# Altium schematic sheet POWER_RF.SchDoc
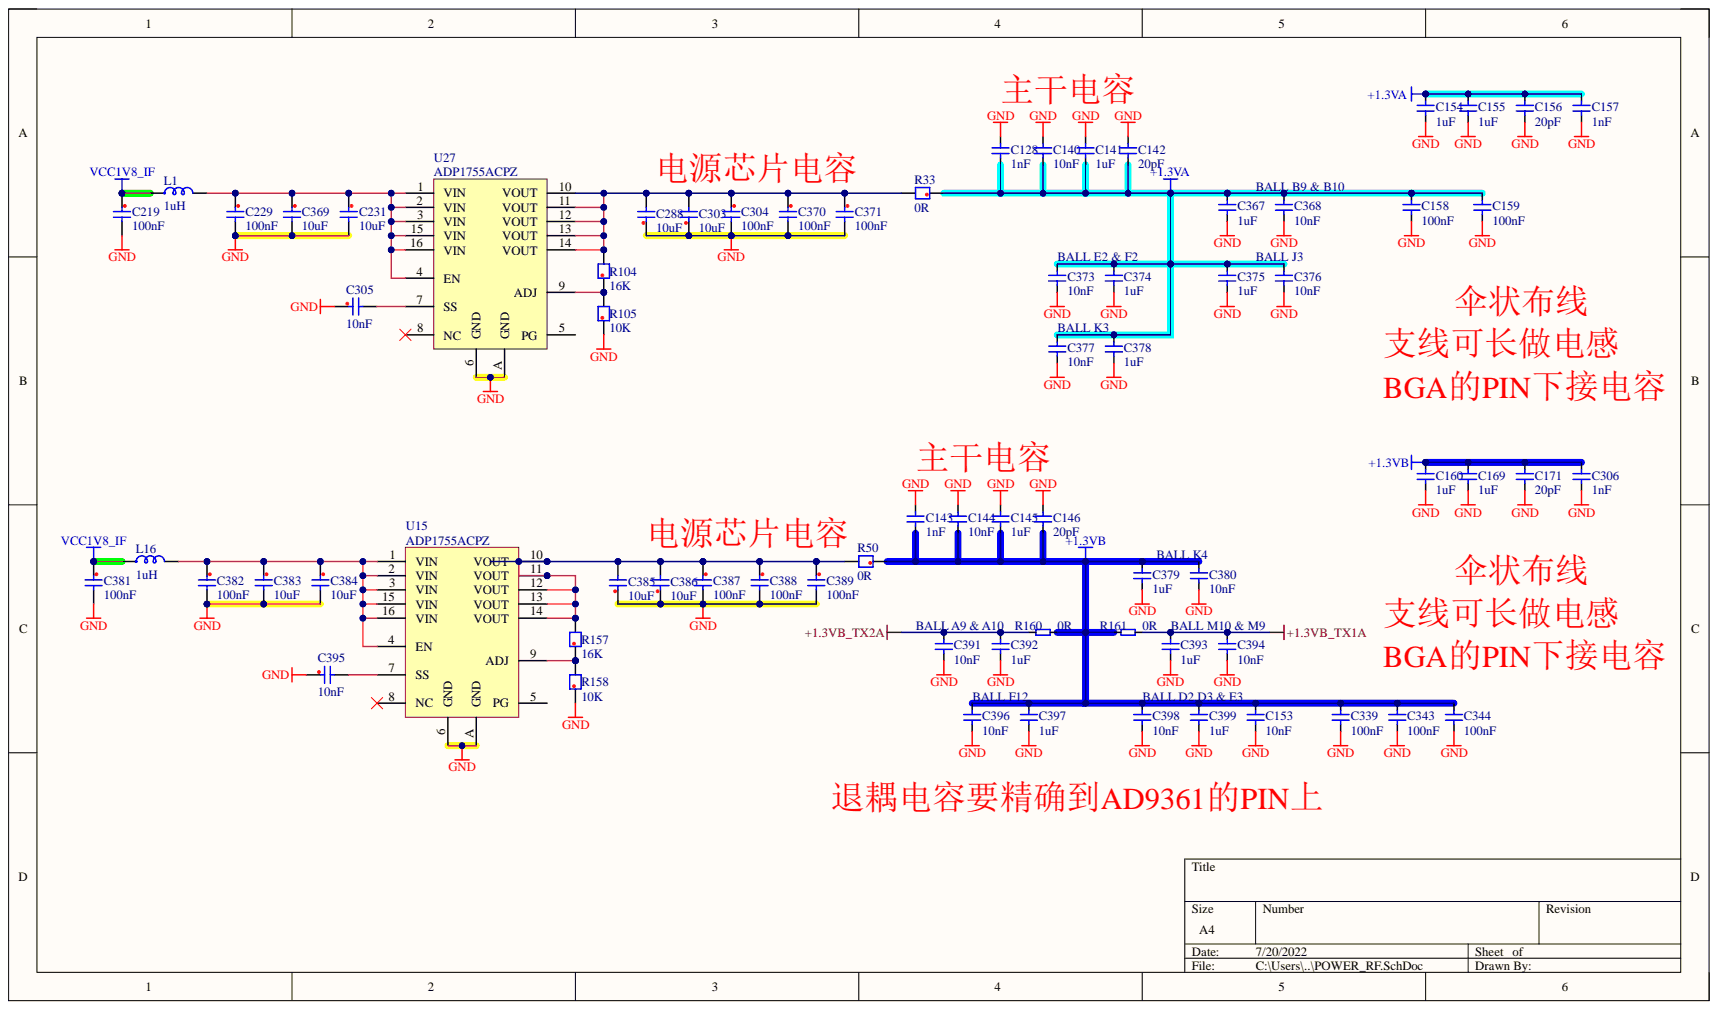
[diagram p0: POWER_RF.SchDoc]

## Parts
C128  1nF  [C0201]
C140  10nF  [C0201]
C141  1uF  [C0402]
C142  20pF  [C0201]
C143  1nF  [C0201]
C144  10nF  [C0201]
C145  1uF  [C0402]
C146  20pF  [C0201]
C153  10nF  [C0201]
C154  1uF  [C0402]
C155  1uF  [C0402]
C156  20pF  [C0201]
C157  1nF  [C0201]
C158  100nF  [C0201]
C159  100nF  [C0201]
C160  1uF  [C0402]
C169  1uF  [C0402]
C171  20pF  [C0201]
C219  100nF  [C0402]
C229  100nF  [C0402]
C231  10uF  [C0603]
C288  10uF  [C0603]
C303  10uF  [C0603]
C304  100nF  [C0201]
C305  10nF  [C0402]
C306  1nF  [C0201]
C339  100nF  [C0201]
C343  100nF  [C0201]
C344  100nF  [C0201]
C367  1uF  [C0402]
C368  10nF  [C0201]
C369  10uF  [C0603]
C370  100nF  [C0201]
C371  100nF  [C0201]
C373  10nF  [C0201]
C374  1uF  [C0402]
C375  1uF  [C0402]
C376  10nF  [C0201]
C377  10nF  [C0201]
C378  1uF  [C0402]
C379  1uF  [C0402]
C380  10nF  [C0201]
C381  100nF  [C0402]
C382  100nF  [C0402]
C383  10uF  [C0603]
C384  10uF  [C0603]
C385  10uF  [C0603]
C386  10uF  [C0603]
C387  100nF  [C0201]
C388  100nF  [C0201]
C389  100nF  [C0201]
C391  10nF  [C0201]
C392  1uF  [C0402]
C393  1uF  [C0402]
C394  10nF  [C0201]
C395  10nF  [C0402]
C396  10nF  [C0201]
C397  1uF  [C0402]
C398  10nF  [C0201]
C399  1uF  [C0402]
L1  1uH  [L0402]
L16  1uH  [L0402]
R33  0R  [R0402]
R50  0R  [R0402]
R104  16K  [R0402]
R105  10K  [R0402]
R157  16K  [R0402]
R158  10K  [R0402]
R160  0R  [R0201]
R161  0R  [R0201]
U15  ADP1755ACPZ  [QFN16A]
U27  ADP1755ACPZ  [QFN16A]

## Nets
+1.3VA: C128.2 C140.2 C141.2 C142.2 C154.2 C155.2 C156.1 C157.1 C158.2 C159.2 C367.2 C368.2 C373.2 C374.2 C375.2 C376.2 C377.2 C378.2 R33.1
+1.3VB: C143.2 C144.2 C145.2 C146.2 C153.2 C160.2 C169.2 C171.1 C306.1 C339.2 C343.2 C344.2 C379.2 C380.2 C396.2 C397.2 C398.2 C399.2 R50.1 R160.1 R161.2
+1.3VB_TX1A: C393.2 C394.2 R161.1
+1.3VB_TX2A: C391.2 C392.2 R160.2
GND: C128.1 C140.1 C141.1 C142.1 C143.1 C144.1 C145.1 C146.1 C153.1 C154.1 C155.1 C156.2 C157.2 C158.1 C159.1 C160.1 C169.1 C171.2 C219.2 C229.2 C231.2 C288.1 C303.1 C304.2 C305.1 C306.2 C339.1 C343.1 C344.1 C367.1 C368.1 C369.2 C370.2 C371.2 C373.1 C374.1 C375.1 C376.1 C377.1 C378.1 C379.1 C380.1 C381.2 C382.2 C383.2 C384.2 C385.1 C386.1 C387.2 C388.2 C389.2 C391.1 C392.1 C393.1 C394.1 C395.1 C396.1 C397.1 C398.1 C399.1 R105.1 R158.1 U15.6(GND) U15.A(GND) U27.6(GND) U27.A(GND)
VCC1V8_IF: C219.1 C381.1 L1.1 L16.1
NetC229_1: C229.1 C231.1 C369.1 L1.2 U27.1(VIN) U27.2(VIN) U27.3(VIN) U27.4(EN) U27.15(VIN) U27.16(VIN)
NetC288_2: C288.2 C303.2 C304.1 C370.1 C371.1 R33.2 R104.2 U27.10(VOUT) U27.11(VOUT) U27.12(VOUT) U27.13(VOUT) U27.14(VOUT)
NetC305_2: C305.2 U27.7(SS)
NetC382_1: C382.1 C383.1 C384.1 L16.2 U15.1(VIN) U15.2(VIN) U15.3(VIN) U15.4(EN) U15.15(VIN) U15.16(VIN)
NetC385_2: C385.2 C386.2 C387.1 C388.1 C389.1 R50.2 R157.2 U15.10(VOUT) U15.11(VOUT) U15.12(VOUT) U15.13(VOUT) U15.14(VOUT)
NetC395_2: C395.2 U15.7(SS)
NetR104_1: R104.1 R105.2 U27.9(ADJ)
NetR157_1: R157.1 R158.2 U15.9(ADJ)

Not connected: U15 5(PG) 8(NC); U27 5(PG) 8(NC)

## Library data of the parts
C128 C140-C146 C153-C160 C169 C171 C306 C339 C343 C344 C367 C368 C373-C380 C391-C394 C396-C399 = C: Capacitor; Component Kind: Standard; Library Name: 电容-SCH.LIB
C219 C229 C231 C288 C303-C305 C369-C371 C381-C389 C395 = CAP: Component Kind: Standard
L1 L16 = INDUCTOR1_1: Inductor; Component Kind: Standard; Library Name: 常用.SchLib
R33 R50 R104 R105 R157 R158 = R: Component Kind: Standard
R160 R161 = RES2: Component Kind: Standard; Library Name: 电阻-SCH.LIB
U15 U27 = ADP1755ACPZ: Component Kind: Standard
